# Altium schematic sheet WiFi_Portable_Sensor_Board_C0_MCU-PWR-UI_20180525.SchDoc
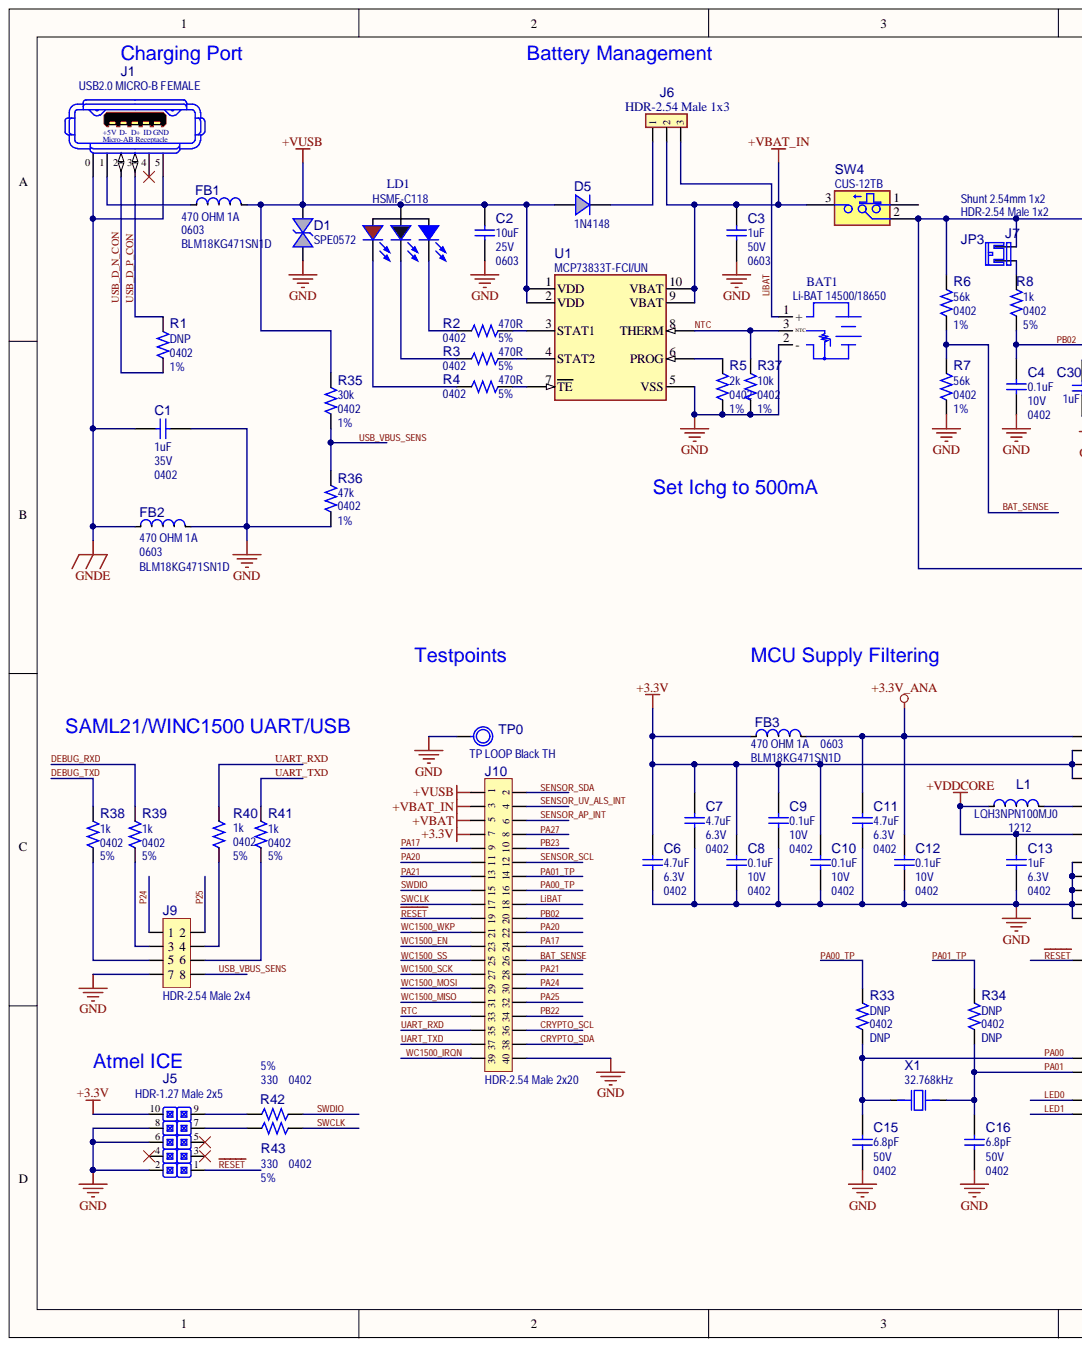
[diagram p0: WiFi_Portable_Sensor_Board_C0_MCU-PWR-UI_20180525.SchDoc, left]
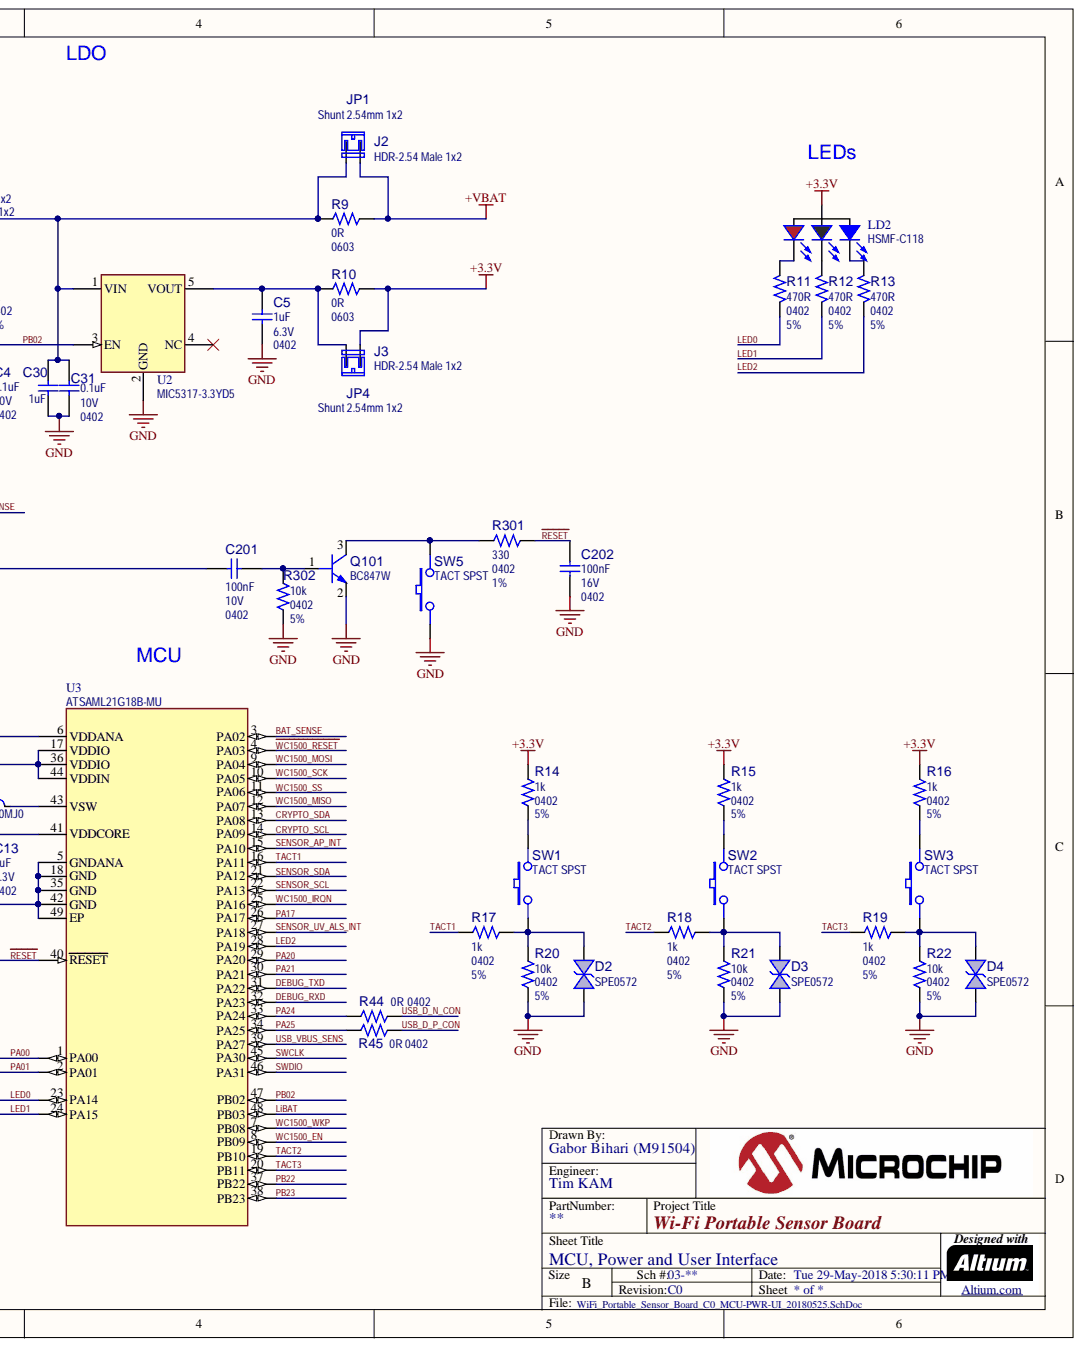
[diagram p1: WiFi_Portable_Sensor_Board_C0_MCU-PWR-UI_20180525.SchDoc, right]

## Parts
BAT1  value Li-BAT 14500/18650  [Battery 3 Pin NTC]  (hidden comment: Battery 3 Pin NTC)
C1  value 1uF  Voltage: 35V  [CAP_C_0402]  (hidden comment: Capacitor NP)
C2  value 10uF  Package: 0603  Voltage: 25V  [CAP_C_0603]  (hidden comment: Capacitor NP)
C3  value 1uF  Package: 0603  Voltage: 50V  [CAP_C_0603]  (hidden comment: Capacitor NP)
C4  value 0.1uF  Package: 0402  Voltage: 10V  [CAP_C_0402]  (hidden comment: Capacitor NP)
C5  value 1uF  Package: 0402  Voltage: 6.3V  [CAP_C_0402]  (hidden comment: Capacitor NP)
C6  value 4.7uF  Package: 0402  Voltage: 6.3V  [CAP_C_0402]  (hidden comment: Capacitor NP)
C7  value 4.7uF  Package: 0402  Voltage: 6.3V  [CAP_C_0402]  (hidden comment: Capacitor NP)
C8  value 0.1uF  Package: 0402  Voltage: 10V  [CAP_C_0402]  (hidden comment: Capacitor NP)
C9  value 0.1uF  Package: 0402  Voltage: 10V  [CAP_C_0402]  (hidden comment: Capacitor NP)
C10  value 0.1uF  Package: 0402  Voltage: 10V  [CAP_C_0402]  (hidden comment: Capacitor NP)
C11  value 4.7uF  Package: 0402  Voltage: 6.3V  [CAP_C_0402]  (hidden comment: Capacitor NP)
C12  value 0.1uF  Package: 0402  Voltage: 10V  [CAP_C_0402]  (hidden comment: Capacitor NP)
C13  value 1uF  Package: 0402  Voltage: 6.3V  [CAP_C_0402]  (hidden comment: Capacitor NP)
C15  value 6.8pF  Package: 0402  Voltage: 50V  [CAP_C_0402]  (hidden comment: Capacitor NP)
C16  value 6.8pF  Package: 0402  Voltage: 50V  [CAP_C_0402]  (hidden comment: Capacitor NP)
C30  value 1uF  [CAP_C_0603]  (hidden comment: Capacitor NP)
C31  value 0.1uF  Package: 0402  Voltage: 10V  [CAP_C_0402]  (hidden comment: Capacitor NP)
C201  value 100nF  Package: 0402  Voltage: 10V  [CAP_C_0402]  (hidden comment: Capacitor NP)
C202  value 100nF  Package: 0402  Voltage: 16V  [CAP_C_0402]  (hidden comment: Capacitor NP)
D1  value SPE0572  [SOD-723]  (hidden comment: Diode TVS Bidirectional)
D2  value SPE0572  [SOD-723]  (hidden comment: Diode TVS Bidirectional)
D3  value SPE0572  [SOD-723]  (hidden comment: Diode TVS Bidirectional)
D4  value SPE0572  [SOD-723]  (hidden comment: Diode TVS Bidirectional)
D5  value 1N4148  [SOD-323]  (hidden comment: Diode Single)
FB1  value 470 OHM 1A  Package: 0603  [IND_C_0603]  (hidden comment: Inductor)
FB2  value 470 OHM 1A  Manufacturer Part Number 1: BLM18KG471SN1D  Package: 0603  [IND_C_0603]  (hidden comment: Inductor)
FB3  value 470 OHM 1A  Manufacturer Part Number 1: BLM18KG471SN1D  Package: 0603  [IND_C_0603]  (hidden comment: Inductor)
J1  value USB2.0 MICRO-B FEMALE  [CON_USB_Micro-AB_SMD_TH_RA_FCI_10118194-0001LF]  (hidden comment: CON USB2.0 Mini_Micro)
J2  value HDR-2.54 Male 1x2  [HDR_M_2.54_1x2]  (hidden comment: Header 1x2)
J3  value HDR-2.54 Male 1x2  [HDR_M_2.54_1x2]  (hidden comment: Header 1x2)
J5  value HDR-1.27 Male 2x5  [HDR_M_1.27_2x5_TH_V_FCI_20021111-00010T4LF]  (hidden comment: Header 2x5)
J6  value HDR-2.54 Male 1x3  [HDR_M_2.54_1x3]  (hidden comment: Header 1x3)
J7  value HDR-2.54 Male 1x2  [HDR_M_2.54_1x2]  (hidden comment: Header 1x2)
J9  value HDR-2.54 Male 2x4  [HDR_M_2.54_2x4]  (hidden comment: Header 2x4)
J10  value HDR-2.54 Male 2x20  [HDR_M_2.54_2x20]  (hidden comment: Header 2x20)
JP1  value Shunt 2.54mm 1x2  [Jumper_Mechanical]  (hidden comment: Jumper Mechanical)
JP3  value Shunt 2.54mm 1x2  [Jumper_Mechanical]  (hidden comment: Jumper Mechanical)
JP4  value Shunt 2.54mm 1x2  [Jumper_Mechanical]  (hidden comment: Jumper Mechanical)
L1  value LQH3NPN100MJ0  [IND_C_1210]  (hidden comment: Inductor)
LD1  value HSMF-C118  Manufacturer Part Number 1: HSMF-C118  [LED_HSMF-C118_L3.2W2.7_RED_GREEN_BLUE]  (hidden comment: LED TRI CA)
LD2  value HSMF-C118  Manufacturer Part Number 1: HSMF-C118  [LED_HSMF-C118_L3.2W2.7_RED_GREEN_BLUE]  (hidden comment: LED TRI CA)
Q101  value BC847W  [SOT-323-3]  (hidden comment: Transistor NPN BEC)
R1  value DNP  Package: 0402  Tolerance: 1%  [RES_C_0402]  (hidden comment: Resistor)
R2  value 470R  Package: 0402  Tolerance: 5%  [RES_C_0402]  (hidden comment: Resistor)
R3  value 470R  Package: 0402  Tolerance: 5%  [RES_C_0402]  (hidden comment: Resistor)
R4  value 470R  Package: 0402  Tolerance: 5%  [RES_C_0402]  (hidden comment: Resistor)
R5  value 2k  Package: 0402  Tolerance: 1%  [RES_C_0402]  (hidden comment: Resistor)
R6  value 56k  Package: 0402  Tolerance: 1%  [RES_C_0402]  (hidden comment: Resistor)
R7  value 56k  Package: 0402  Tolerance: 1%  [RES_C_0402]  (hidden comment: Resistor)
R8  value 1k  Package: 0402  Tolerance: 5%  [RES_C_0402]  (hidden comment: Resistor)
R9  value 0R  Package: 0603  [RES_C_0603]  (hidden comment: Resistor)
R10  value 0R  Package: 0603  [RES_C_0603]  (hidden comment: Resistor)
R11  value 470R  Package: 0402  Tolerance: 5%  [RES_C_0402]  (hidden comment: Resistor)
R12  value 470R  Package: 0402  Tolerance: 5%  [RES_C_0402]  (hidden comment: Resistor)
R13  value 470R  Package: 0402  Tolerance: 5%  [RES_C_0402]  (hidden comment: Resistor)
R14  value 1k  Package: 0402  Tolerance: 5%  [RES_C_0402]  (hidden comment: Resistor)
R15  value 1k  Package: 0402  Tolerance: 5%  [RES_C_0402]  (hidden comment: Resistor)
R16  value 1k  Package: 0402  Tolerance: 5%  [RES_C_0402]  (hidden comment: Resistor)
R17  value 1k  Package: 0402  Tolerance: 5%  [RES_C_0402]  (hidden comment: Resistor)
R18  value 1k  Package: 0402  Tolerance: 5%  [RES_C_0402]  (hidden comment: Resistor)
R19  value 1k  Package: 0402  Tolerance: 5%  [RES_C_0402]  (hidden comment: Resistor)
R20  value 10k  Package: 0402  Tolerance: 5%  [RES_C_0402]  (hidden comment: Resistor)
R21  value 10k  Package: 0402  Tolerance: 5%  [RES_C_0402]  (hidden comment: Resistor)
R22  value 10k  Package: 0402  Tolerance: 5%  [RES_C_0402]  (hidden comment: Resistor)
R33  value DNP  Package: 0402  Populated: DNP  [RES_C_0402]  (hidden comment: Resistor)
R34  value DNP  Package: 0402  Populated: DNP  [RES_C_0402]  (hidden comment: Resistor)
R35  value 30k  Package: 0402  Tolerance: 1%  [RES_C_0402]  (hidden comment: Resistor)
R36  value 47k  Package: 0402  Tolerance: 1%  [RES_C_0402]  (hidden comment: Resistor)
R37  value 10k  Package: 0402  Tolerance: 1%  [RES_C_0402]  (hidden comment: Resistor)
R38  value 1k  Package: 0402  Populated: 5%  [RES_C_0402]  (hidden comment: Resistor)
R39  value 1k  Package: 0402  Populated: 5%  [RES_C_0402]  (hidden comment: Resistor)
R40  value 1k  Populated: 5%  [RES_C_0402]  (hidden comment: Resistor)
R41  value 1k  Package: 0402  Populated: 5%  [RES_C_0402]  (hidden comment: Resistor)
R42  value 330  Package: 0402  [RES_C_0402]  (hidden comment: Resistor)
R43  value 330  Package: 0402  [RES_C_0402]  (hidden comment: Resistor)
R44  value 0R  Package: 0402  [RES_C_0402]  (hidden comment: Resistor)
R45  value 0R  Package: 0402  [RES_C_0402]  (hidden comment: Resistor)
R301  value 330  Package: 0402  Tolerance: 1%  [RES_C_0402]  (hidden comment: Resistor)
R302  value 10k  Package: 0402  Tolerance: 5%  [RES_C_0402]  (hidden comment: Resistor)
SW1  value TACT SPST  [SW_TACT_SMD_CK_PTS635SL25SMTR]  (hidden comment: Switch 2 Pin)
SW2  value TACT SPST  [SW_TACT_SMD_CK_PTS635SL25SMTR]  (hidden comment: Switch 2 Pin)
SW3  value TACT SPST  [SW_TACT_SMD_CK_PTS635SL25SMTR]  (hidden comment: Switch 2 Pin)
SW4  value CUS-12TB  Manufacturer Part Number 1: CUS-12TB  [SW_SLIDE_SMD_SPDT_RA_CUS-12B]  (hidden comment: SWITCH SLIDE 3 Pin SPDT)
SW5  value TACT SPST  [SW_TACT_SMD_CK_PTS635SL25SMTR]  (hidden comment: Switch 2 Pin)
TP0  value TP LOOP Black TH  [CON_TP_TH_V_BLK_KEC_5011]  (hidden comment: Test Point)
U1  value MCP73833T-FCI/UN  Manufacturer Part Number 1: MCP73833T-FCI/UN  [MSOP-10]  (hidden comment: MCP73833_4 MSOP-10)
U2  value MIC5317-3.3YD5  [TSOT-23-5]  (hidden comment: MIC5317 FIX TSOT-23-5)
U3  value ATSAML21G18B-MU  [QFN-48_7x7_EP5.1x5.1]  (hidden comment: ATSAML21G18 QFN-48)
X1  value 32.768kHz  [CRYSTAL_ABS07]  (hidden comment: Crystal 2 Pins)

## Nets
+3.3V: C6.2 C7.2 C8.2 C9.2 C10.2 FB3.1 J3.2 J5.10 J10.7 LD2.1 R10.2 R14.2 R15.2 R16.2 U3.17(VDDIO) U3.36(VDDIO) U3.44(VDDIN)
+3.3V_ANA: C11.2 C12.2 FB3.2 U3.6(VDDANA)
+VBAT: J2.2 J10.5 R9.2
+VBAT_IN: C3.2 J6.2 J10.3 SW4.3 U1.9(VBAT) U1.10(VBAT)
+VDDCORE: C13.2 L1.1 U3.41(VDDCORE)
+VUSB: C2.2 D1.2 D5.1 FB1.2 J10.1 LD1.1 R35.2 U1.1(VDD) U1.2(VDD)
GND: BAT1.2 C1.2 C2.1 C3.1 C4.1 C5.1 C6.1 C7.1 C8.1 C9.1 C10.1 C11.1 C12.1 C13.1 C15.1 C16.1 C30.1 C31.1 C202.1 D1.1 D2.1 D3.1 D4.1 FB2.2 J5.2 J5.6 J5.8 J9.7 J10.40 Q101.2 R5.1 R7.1 R20.1 R21.1 R22.1 R36.1 R37.1 R302.1 SW5.1 TP0.1 U1.5(VSS) U2.2(GND) U3.5(GNDANA) U3.18(GND) U3.35(GND) U3.42(GND) U3.49(EP)
GNDE: C1.1 FB2.1 J1.0 J1.5
BAT_SENSE: J10.26 R6.1 R7.2 U3.3(PA02)
CRYPTO_SCL: J10.36 U3.14(PA09)
CRYPTO_SDA: J10.38 U3.13(PA08)
DEBUG_RXD: R39.2 U3.32(PA23)
DEBUG_TXD: R38.2 U3.31(PA22)
LED0: R11.2 U3.23(PA14)
LED1: R12.2 U3.24(PA15)
LED2: R13.2 U3.28(PA19)
LiBAT: BAT1.1 J6.3 J10.18 U3.48(PB03)
NTC: BAT1.3 R37.2 U1.8(THERM)
P24: J9.1
P25: J9.2
PA00: C15.2 R33.1 U3.1(PA00) X1.1
PA00_TP: J10.16 R33.2
PA01: C16.2 R34.1 U3.2(PA01) X1.2
PA01_TP: J10.14 R34.2
PA17: J10.9 J10.24 U3.26(PA17)
PA20: J10.11 J10.22 U3.29(PA20)
PA21: J10.13 J10.28 U3.30(PA21)
PA24: J10.30 R44.1 U3.33(PA24)
PA25: J10.32 R45.1 U3.34(PA25)
PA27: J10.8
PB02: C4.2 J10.20 R8.1 U2.3(EN) U3.47(PB02)
PB22: J10.34 U3.37(PB22)
PB23: J10.10 U3.38(PB23)
~{RESET}: C202.2 J5.1 J10.19 R301.1 U3.40(RESET)
RTC: J10.33
SENSOR_AP_INT: J10.6 U3.15(PA10)
SENSOR_SCL: J10.12 U3.22(PA13)
SENSOR_SDA: J10.2 U3.21(PA12)
SENSOR_UV_ALS_INT: J10.4 U3.27(PA18)
SWCLK: J10.17 R43.1 U3.45(PA30)
SWDIO: J10.15 R42.1 U3.46(PA31)
TACT1: R17.2 U3.16(PA11)
TACT2: R18.2 U3.19(PB10)
TACT3: R19.2 U3.20(PB11)
UART_RXD: J10.35 R40.2
UART_TXD: J10.37 R41.2
USB_D_N_CON: J1.2 R1.1 R44.2
USB_D_P_CON: J1.3(D+) R1.2 R45.2
USB_VBUS_SENS: J9.8 R35.1 R36.2 U3.39(PA27)
~{WC1500_RESET}: U3.4(PA03)
WC1500_EN: J10.23 U3.8(PB09)
WC1500_IRQN: J10.39 U3.25(PA16)
WC1500_MISO: J10.31 U3.12(PA07)
WC1500_MOSI: J10.29 U3.9(PA04)
WC1500_SCK: J10.27 U3.10(PA05)
WC1500_SS: J10.25 U3.11(PA06)
WC1500_WKP: J10.21 U3.7(PB08)
NetC5_2: C5.2 J3.1 R10.1 U2.5(VOUT)
NetC30_2: C30.2 C31.2 C201.2 J2.1 J7.1 R6.2 R9.1 SW4.2 U2.1(VIN)
NetC201_1: C201.1 Q101.1 R302.2
NetD2_2: D2.2 R17.1 R20.2 SW1.1
NetD3_2: D3.2 R18.1 R21.2 SW2.1
NetD4_2: D4.2 R19.1 R22.2 SW3.1
NetD5_2: D5.2 J6.1
NetFB1_1: FB1.1 J1.1
NetJ5_7: J5.7 R43.2
NetJ5_9: J5.9 R42.2
NetJ7_2: J7.2 R8.2
NetJ9_3: J9.3 R39.1
NetJ9_4: J9.4 R40.1
NetJ9_5: J9.5 R38.1
NetJ9_6: J9.6 R41.1
NetL1_2: L1.2 U3.43(VSW)
NetLD1_2: LD1.2 R4.2
NetLD1_3: LD1.3 R3.2
NetLD1_4: LD1.4 R2.2
NetLD2_2: LD2.2 R11.1
NetLD2_3: LD2.3 R12.1
NetLD2_4: LD2.4 R13.1
NetQ101_3: Q101.3 R301.2 SW5.2
NetR2_1: R2.1 U1.3(STAT1)
NetR3_1: R3.1 U1.4(STAT2)
NetR4_1: R4.1 U1.7(TE)
NetR5_2: R5.2 U1.6(PROG)
NetR14_1: R14.1 SW1.2
NetR15_1: R15.1 SW2.2
NetR16_1: R16.1 SW3.2

Not connected: J1 4(ID); J5 3 4 5; SW4 1; U2 4(NC)
